# Altium schematic sheet [7] Accumulator_Indicator.SchDoc
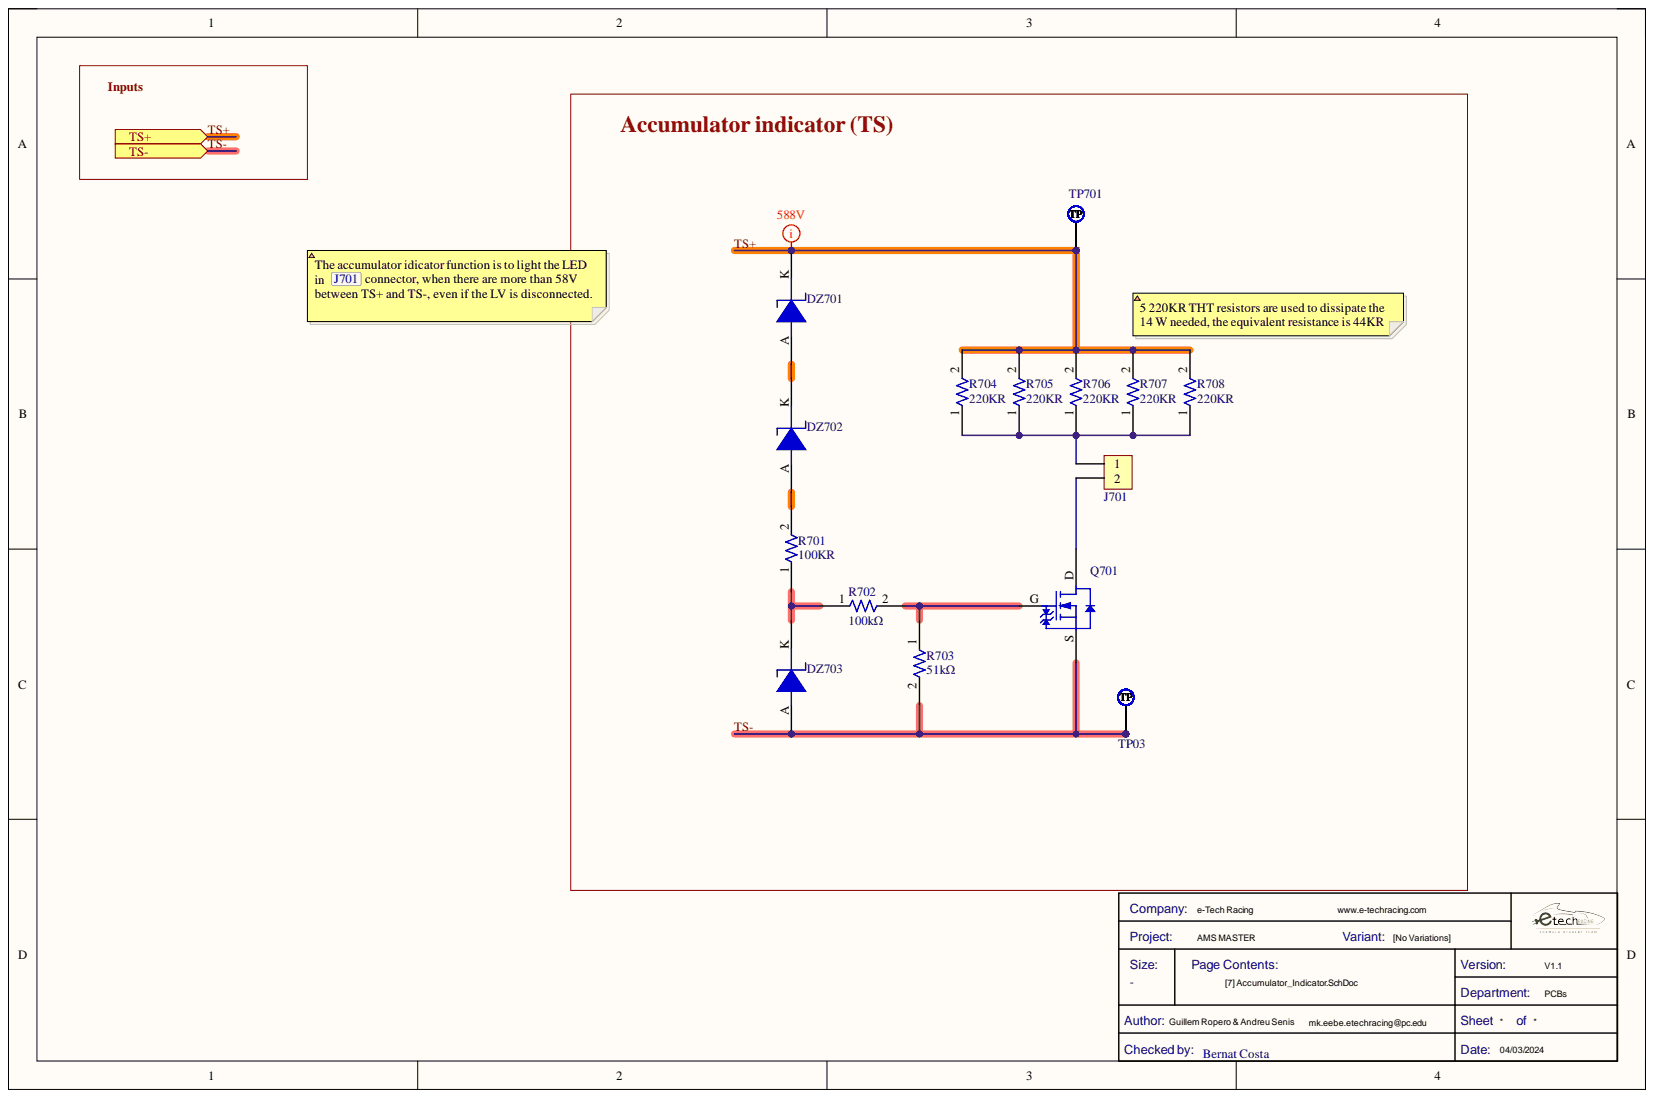
[diagram p0: [7] Accumulator_Indicator.SchDoc]

## Parts
DZ701  DZ_24V  [DZ_24V]  (value not drawn)
DZ702  DZ_24V  [DZ_24V]  (value not drawn)
DZ703  DZ_10V  [SOD123]  (value not drawn)
J701  105309-2202  [J_NanoFit_1x2_V]  (value not drawn)
Q701  IRLR2905  [IRLR2905]  (value not drawn)
R701  100KR  [THT_3W_Resistor]
R702  Resistance: 100kΩ  [R1206FTK-W]  (hidden comment: CRG1206F100R)
R703  Resistance: 51kΩ  [R1206FTK-W]  (hidden comment: CRCW120610K0FKEA)
R704  220KR  [THT_3W_Resistor]
R705  220KR  [THT_3W_Resistor]
R706  220KR  [THT_3W_Resistor]
R707  220KR  [THT_3W_Resistor]
R708  220KR  [THT_3W_Resistor]
TP03  TestPoint  [TestPoint]  (value not drawn)  (no BOM)
TP701  TestPoint  [TestPoint]  (value not drawn)  (no BOM)

## Ports
TS+, TS-

## Nets
TS+: DZ701.K R704.2 R705.2 R706.2 R707.2 R708.2 TP701.1 ; port TS+
TS-: DZ703.A Q701.S R703.2 TP03.1 ; port TS-
NetDZ701_A: DZ701.A DZ702.K
NetDZ702_A: DZ702.A R701.2
NetDZ703_K: DZ703.K R701.1 R702.1
NetJ701_1: J701.1 R704.1 R705.1 R706.1 R707.1 R708.1
NetJ701_2: J701.2 Q701.D
NetQ701_G: Q701.G R702.2 R703.1

## Library data of the parts
DZ701 DZ702 = DZ_24V: 3.0 W Zener Diode Voltage Regulator 24 V (Automotive); Manufacturer: Diodes; Manufacturer Part Number: BZT52C5V1-7-F; Forward Current: 10mA; Impedance: 19R; Max Power Dissipation: 3W; Max Reverse Leakage Current: 1uA; Tolerance: 5%; Zener Voltage: 24V; Case/Package: SMB; Category: Zener Diodes; Component Kind: Standard; ComponentLink1Description: https://datasheet.ciiva.com/15142/getdatasheetpartid-75143-15142417.pdf?src-supplier=Verical; ComponentLink1URL: https://datasheet.ciiva.com/15142/getdatasheetpartid-75143-15142417.pdf?src-supplier=Verical; ComponentLink2Description: https://datasheet.ciiva.com/4734/ds18004-73542-4734246.pdf?src-supplier=Mouser; ComponentLink2URL: https://datasheet.ciiva.com/4734/ds18004-73542-4734246.pdf?src-supplier=Mouser; ComponentLink3Description: https://datasheet.ciiva.com/22713/1670962-22713975.pdf?src-supplier=Element14; ComponentLink3URL: https://datasheet.ciiva.com/22713/1670962-22713975.pdf?src-supplier=Element14; ComponentLink4Description: https://datasheet.ciiva.com/10638/1smb5913bt3-d-10638009.pdf?src-supplier=Avnet; ComponentLink4URL: https://datasheet.ciiva.com/10638/1smb5913bt3-d-10638009.pdf?src-supplier=Avnet; Halogen Free: Halogen Free; Lead Free: Lead Free; Library Name: Semiconductor.SchLib; Max Operating Temperature: 150°C; Min Operating Temperature: -65°C; Packaging: Tape and Reel; Pins: 2; Pricing 1: 1=0,79, 10=0,685, 100=0,4743, 500=0,39628, 1000=0,33726 (USD); Radiation Hardening: No; RoHS: Yes; RoHS Compliant: Yes; Series: Automotive, AEC-Q101; Stock 1: 2118; Supplier 1: Digi-Key; Supplier Part Number 1: 488-SZ1SMB5934BT3GCT-ND
DZ703 = DZ_10V: BZT52C5V1 Series 500 mW 10V 10 mA Surface Mount Zener Diode - SOD-123; Manufacturer: Diodes; Manufacturer Part Number: BZT52C5V1-7-F; Forward Current: 10mA; Category: Zener Diodes; Component Kind: Standard; ComponentLink1Description: https://datasheet.ciiva.com/15142/getdatasheetpartid-75143-15142417.pdf?src-supplier=Verical; ComponentLink1URL: https://datasheet.ciiva.com/15142/getdatasheetpartid-75143-15142417.pdf?src-supplier=Verical; ComponentLink2Description: https://datasheet.ciiva.com/4734/ds18004-73542-4734246.pdf?src-supplier=Mouser; ComponentLink2URL: https://datasheet.ciiva.com/4734/ds18004-73542-4734246.pdf?src-supplier=Mouser; ComponentLink3Description: https://datasheet.ciiva.com/22713/1670962-22713975.pdf?src-supplier=Element14; ComponentLink3URL: https://datasheet.ciiva.com/22713/1670962-22713975.pdf?src-supplier=Element14; Library Name: Semiconductor.SchLib; Pricing 1: 3000=0,0147, 12000=0,0141, 45000=0,0135, 90000=0,0132, 225000=0,0126 (USD); RoHS: Yes; Stock 1: 678000; Supplier 1: Future Electronics; Supplier Part Number 1: 9109935
J701 = J_Nanofit_1x2_V: Nano-Fit Vertical Header, Through Hole, 2.50mm Pitch, Single Row, 2 Circuits, with Kinked Pins, Tin (Sn) Plating,...; Manufacturer: Molex; Category: Uncategorized; Component Kind: Standard; ComponentLink1Description: https://www.molex.com/pdm_docs/sd/1053092202_sd.pdf?src-supplier=Digi-Key; ComponentLink1URL: https://www.molex.com/pdm_docs/sd/1053092202_sd.pdf?src-supplier=Digi-Key; Library Name: Electromechanical.SchLib; Manufacturer Part Number: 105309-2202; Pricing 1: 1=1,1, 10=0,888, 50=0,888, 100=0,735, 1000=0,59, 10000=0,515 (USD); RoHS: Yes; Stock 1: 6319; Supplier 1: Mouser; Supplier Part Number 1: 538-105309-2202
Q701 = TRT-NCH-55V-42A: MOSFET N-CH 55V 42A DPAK; Manufacturer: Infineon; Manufacturer Part Number: IRLR2905TRPBF; Manufacturer Part Number 1: IRFR3410TRPBF; Continuous Drain Current (ID): 31A; Drain to Source Breakdown Voltage: 100V; Drain to Source Resistance: 39mR; Gate to Source Voltage (Vgs): 20V; Input Capacitance: 1.69nF; Max Power Dissipation: 3W; Polarization: N; Power Dissipation: 110W; Threshold Voltage: 4V; Turn-Off Delay Time: 40ns; Turn-On Delay Time: 12ns; Case/Package: TO-252-3; Category: MOSFETs; Component Kind: Standard; ComponentLink2Description: Datasheet; ComponentLink2URL: https://www.mouser.es/datasheet/2/427/sq2318bes-1868475.pdf; Drain to Source Voltage (Vdss): 100V; Halogen Free: Halogen Free; Height: 0.094inch; Lead Free: Contains Lead; Length: 0.264inch; Library Name: Semiconductor.SchLib; Manufacturer 1: Infineon; Max Dual Supply Voltage: 100V; Max Operating Temperature: 175°C; Min Operating Temperature: -55°C; Mount: Surface Mount; Number of Pins: 3; Packaging: Cut Tape; Pricing 1: 1=1,37, 10=1,13, 50=1,13, 100=0,87, 1000=0,615, 10000=0,525 (USD); Rds On Max: 39mR; Reach SVHC Compliant: Yes; RoHS: Yes; RoHS Compliant: Yes; Series: HEXFET®; Stock 1: 239; Supplier 1: Mouser; Supplier Part Number 1: 942-IRLR2905TRPBF; Width: 0.245inch
R701 = R100K_3W_THT: Component Kind: Standard; Library Name: Passives.SchLib
R702 = R100-1206FTK: Res Thick Film 1206 100 Ohm 1% 0.25W(1/4W) ±200ppm/C Pad SMD T/R; Manufacturer: TE Connectivity; .Creator: AC; Max Power Dissipation: 250mW; Number of Resistors: 1; Temperature Coefficient: 200ppm/°C; Voltage Rating: 200V; Case Code (Imperial): 1206; Case Code (Metric): 3216; Case/Package: 1206; Category: Resistor; Component Kind: Standard; ComponentLink1Description: Datasheet; ComponentLink1URL: https://docs.rs-online.com/7aab/0900766b815b7339.pdf; ComponentLink2Description: Where buy it; ComponentLink2URL: https://es.rs-online.com/web/p/resistencias-de-montaje-en-superficie/2232120; ComponentLink3Description: https://datasheet.ciiva.com/11808/0900766b800a696e-11808648.pdf?src-supplier=RSComponents; ComponentLink3URL: https://datasheet.ciiva.com/11808/0900766b800a696e-11808648.pdf?src-supplier=RSComponents; ComponentLink4Description: https://datasheet.ciiva.com/16763/70063020-16763547.pdf?src-supplier=Allied+Electronics; ComponentLink4URL: https://datasheet.ciiva.com/16763/70063020-16763547.pdf?src-supplier=Allied+Electronics; ComponentLink5Description: https://datasheet.ciiva.com/5562/eng-ds-1773204-g-5562604.pdf?src-supplier=Mouser; ComponentLink5URL: https://datasheet.ciiva.com/5562/eng-ds-1773204-g-5562604.pdf?src-supplier=Mouser; Depth: 1.55mm; ELV: Compliant; Height: 0.55mm; Length: 3.1mm; Library Name: Passives.SchLib; Manufacturer Part Number: CRG1206F100R; Max Operating Temperature: 125°C; Min Operating Temperature: -55°C; Mount: Surface Mount; Number of Terminations: 2; Package Quantity: 5000; Packaging: Tape and Reel; Power Rating: 250mW; Pricing 1: 1=0,10000, 10=0,07600, 100=0,02920, 1000=0,01285, 2500=0,01110 (USD); Radiation Hardening: No; Resistor Type: Thick Film; RoHS: Yes; RoHS Compliant: Yes; Series: CRG, Neohm; Stock 1: 13476; Supplier 1: Digi-Key; Supplier Part Number 1: A106068CT-ND; Technology: THICK FILM; Termination: Solder; Tolerance: 1%; Weight: 0.000571oz; Width: 1.55mm
R703 = R51k-1206FTK: Res Thick Film 1206 51K Ohm 1% 1/4W ±100ppm/°C Molded SMD SMD Punched Carrier T/R; Manufacturer: Panasonic; Manufacturer Part Number: ERJ-S08F5102V; .Creator: DO; Current Rating: 2A; Max Power Dissipation: 250mW; Voltage Rating: 150V; Voltage Rating (DC): 200V; Case Code (Imperial): 1206; Case Code (Metric): 3216; Case/Package: 1206; Category: Resistor; Component Kind: Standard; ComponentLink1Description: Datasheet; ComponentLink1URL: https://docs.rs-online.com/bca8/A700000006404581.pdf; ComponentLink2Description: Where buy it; ComponentLink2URL: https://es.rs-online.com/web/p/resistencias-de-montaje-en-superficie/6791765; ComponentLink3Description: https://datasheet.ciiva.com/24023/aoa0000ce5-24023518.pdf?src-supplier=Mouser; ComponentLink3URL: https://datasheet.ciiva.com/24023/aoa0000ce5-24023518.pdf?src-supplier=Mouser; ComponentLink4Description: https://datasheet.ciiva.com/9189/aoa0000ce5-9189211.pdf?src-supplier=Avnet; ComponentLink4URL: https://datasheet.ciiva.com/9189/aoa0000ce5-9189211.pdf?src-supplier=Avnet; Construction: Rectangular; Contact Plating: Tin; Depth: 1.6mm; Features: Anti-Sulfur; Height: 0.028inch; Lead Free: Lead Free; Length: 3.2mm; Library Name: Passives.SchLib; Max Operating Temperature: 155°C; Military Standard: Not; Min Operating Temperature: -55°C; Mount: Surface Mount; Number of Terminations: 2; Package Quantity: 5000; Packaging: Tape and Reel; Power Rating: 250mW; Pricing 1: 1=0,31000, 10=0,26700, 50=0,26700, 100=0,10700, 1000=0,05100, 10000=0,03400 (USD); Resistor Type: Thick Film; RoHS: Yes; RoHS Compliant: Yes; Series: ERJ; Stock 1: 4091; Supplier 1: Mouser; Supplier Part Number 1: 667-ERJ-S08F5102V; Temperature Coefficient: 100ppm/°C; Tolerance: 1%; Width: 0.063inch
R704-R708 = R220K_3W_THT: Component Kind: Standard; Library Name: Passives.SchLib
TP03 TP701 = TestPoint: Test Point 1x1 mm; .Creator: GP; Category: TestPoint; Library Name: e-Tech_Racing.SchLib; .PCBcheck: GP; .SCHcheck: GP
